# Altium schematic sheet [05] - PERIFERALS DIAGRAM.SchDoc
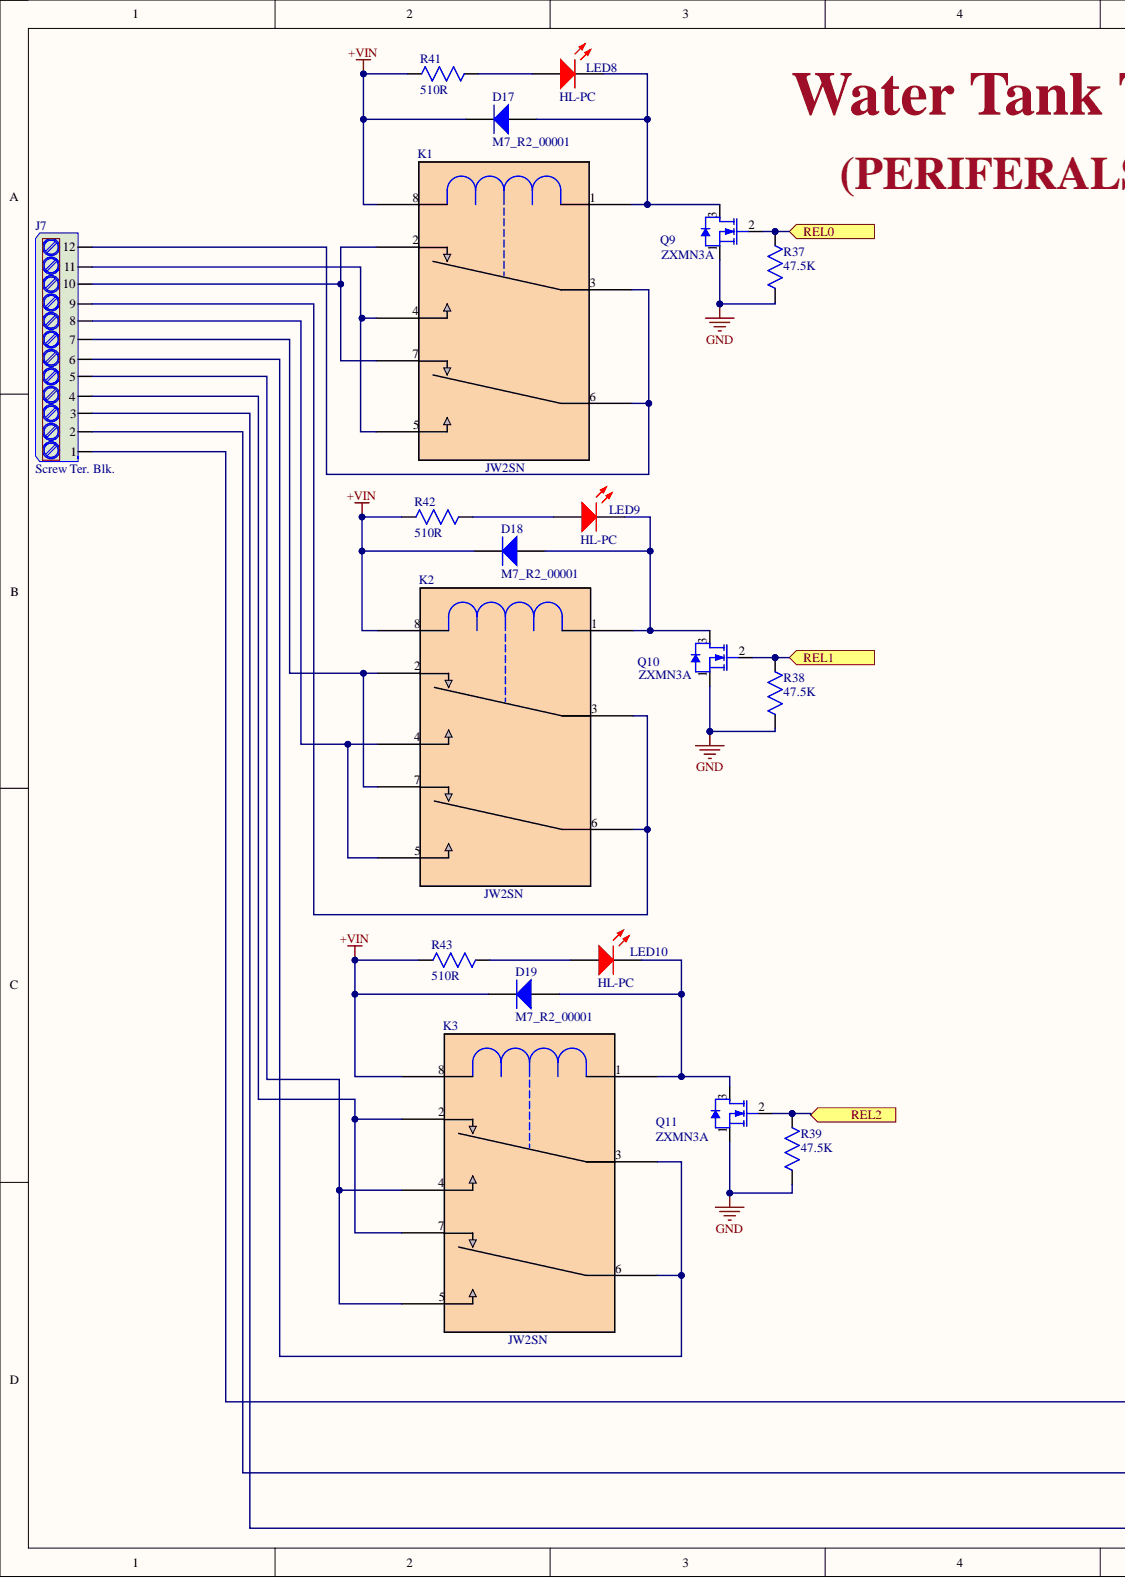
[diagram p0: [05] - PERIFERALS DIAGRAM.SchDoc, left]
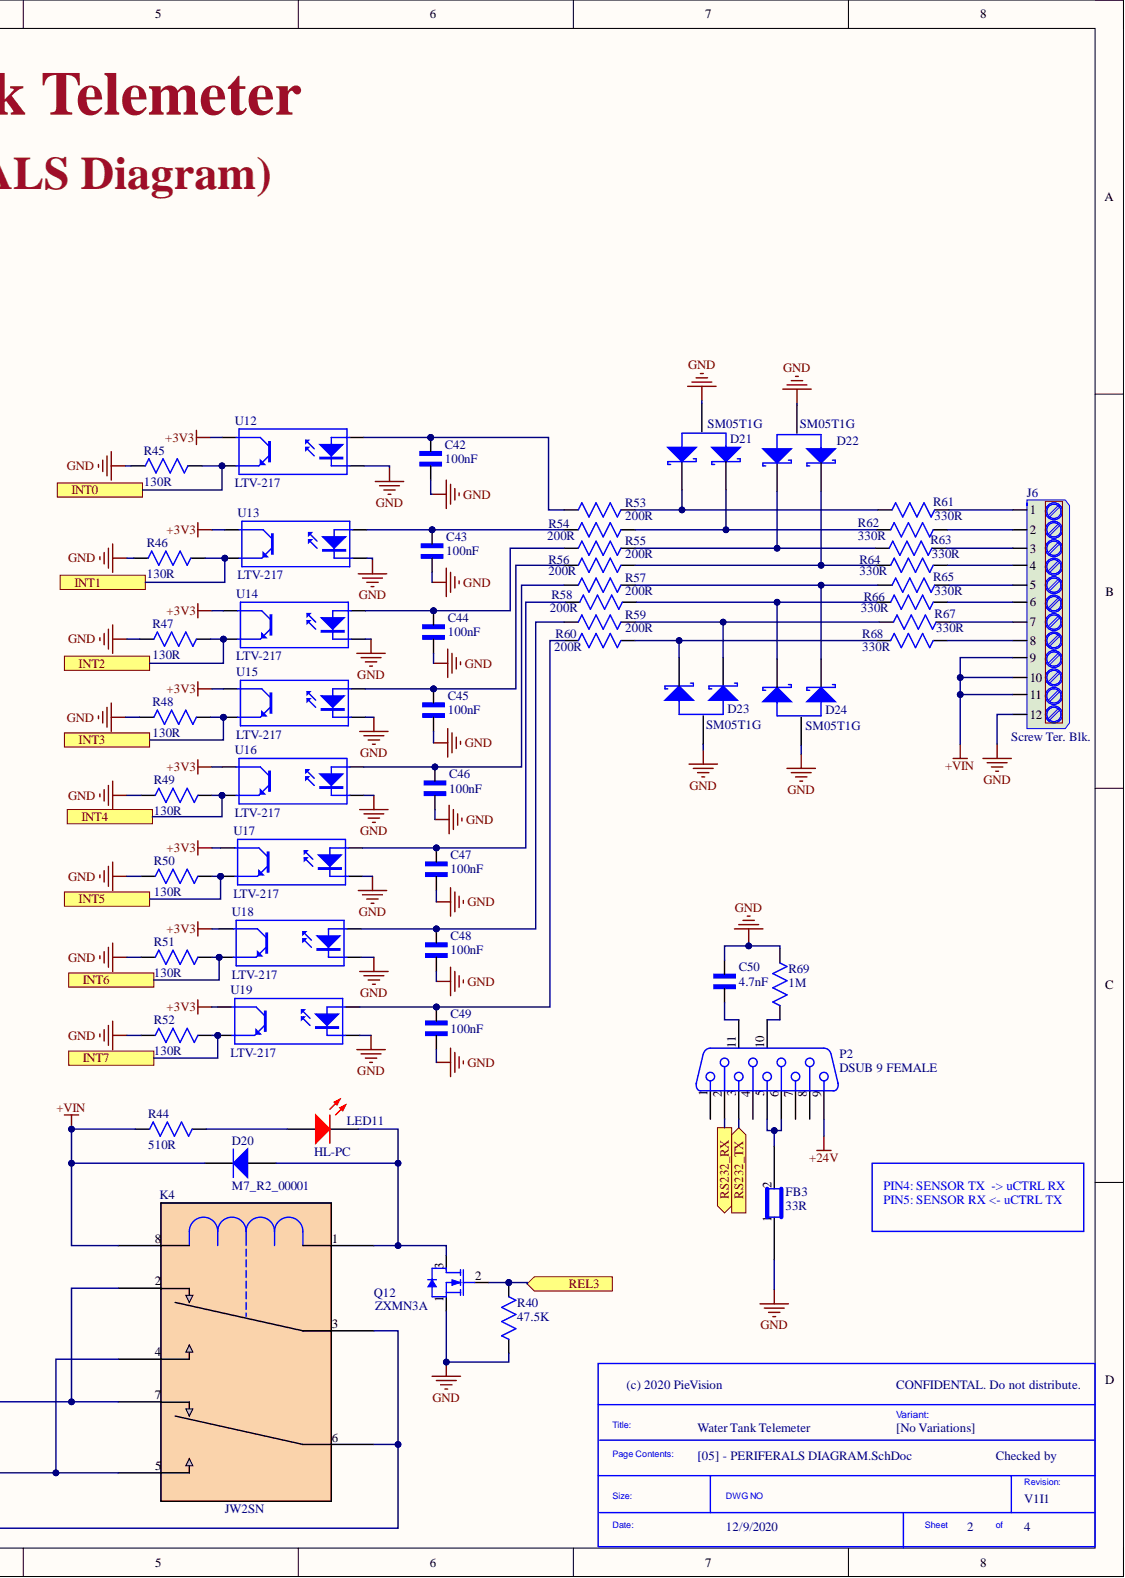
[diagram p1: [05] - PERIFERALS DIAGRAM.SchDoc, right]

## Parts
C42  100nF  [CAP_0805]
C43  100nF  [CAP_0805]
C44  100nF  [CAP_0805]
C45  100nF  [CAP_0805]
C46  100nF  [CAP_0805]
C47  100nF  [CAP_0805]
C48  100nF  [CAP_0805]
C49  100nF  [CAP_0805]
C50  4.7nF  [CAP_0805]
D17  M7_R2_00001  [DID_SMA]
D18  M7_R2_00001  [DID_SMA]
D19  M7_R2_00001  [DID_SMA]
D20  M7_R2_00001  [DID_SMA]
D21  SM05T1G  [SOT-23]
D22  SM05T1G  [SOT-23]
D23  SM05T1G  [SOT-23]
D24  SM05T1G  [SOT-23]
FB3  33R  [FB_0603]
J6  Screw Ter. Blk.  [TERM_BLK_12P]
J7  Screw Ter. Blk.  [TERM_BLK_12P]
K1  JW2SN  [RELAY_DPDT]
K2  JW2SN  [RELAY_DPDT]
K3  JW2SN  [RELAY_DPDT]
K4  JW2SN  [RELAY_DPDT]
LED8  HL-PC  [LED_RED_1206]
LED9  HL-PC  [LED_RED_1206]
LED10  HL-PC  [LED_RED_1206]
LED11  HL-PC  [LED_RED_1206]
P2  DSUB 9 FEMALE  [DSUB9_VF]
Q9  ZXMN3A  [SOT-23]
Q10  ZXMN3A  [SOT-23]
Q11  ZXMN3A  [SOT-23]
Q12  ZXMN3A  [SOT-23]
R37  47.5K  [RES_0805]
R38  47.5K  [RES_0805]
R39  47.5K  [RES_0805]
R40  47.5K  [RES_0805]
R41  510R  [RES_1206]
R42  510R  [RES_1206]
R43  510R  [RES_1206]
R44  510R  [RES_1206]
R45  130R  [RES_0805]
R46  130R  [RES_0805]
R47  130R  [RES_0805]
R48  130R  [RES_0805]
R49  130R  [RES_0805]
R50  130R  [RES_0805]
R51  130R  [RES_0805]
R52  130R  [RES_0805]
R53  200R  [RES_1206]
R54  200R  [RES_1206]
R55  200R  [RES_1206]
R56  200R  [RES_1206]
R57  200R  [RES_1206]
R58  200R  [RES_1206]
R59  200R  [RES_1206]
R60  200R  [RES_1206]
R61  330R  [RES_1206]
R62  330R  [RES_1206]
R63  330R  [RES_1206]
R64  330R  [RES_1206]
R65  330R  [RES_1206]
R66  330R  [RES_1206]
R67  330R  [RES_1206]
R68  330R  [RES_1206]
R69  1M  [RES_0805]
U12  LTV-217  [SSOP-4]
U13  LTV-217  [SSOP-4]
U14  LTV-217  [SSOP-4]
U15  LTV-217  [SSOP-4]
U16  LTV-217  [SSOP-4]
U17  LTV-217  [SSOP-4]
U18  LTV-217  [SSOP-4]
U19  LTV-217  [SSOP-4]

## Ports
INT0, INT1, INT2, INT3, INT4, INT5, INT6, INT7, REL0, REL1, REL2, REL3, RS232_RX, RS232_TX

## Nets
+3V3: U12.4 U13.4 U14.4 U15.4 U16.4 U17.4 U18.4 U19.4
+24V: P2.9
+VIN: D17.2 D18.2 D19.2 D20.2 J6.9 J6.10 J6.11 K1.8 K2.8 K3.8 K4.8 R41.2 R42.2 R43.2 R44.2
GND: C42.2 C43.2 C44.2 C45.2 C46.2 C47.2 C48.2 C49.2 C50.1 D21.3 D22.3 D23.3 D24.3 FB3.1 J6.12 Q9.1 Q10.1 Q11.1 Q12.1 R37.2 R38.2 R39.2 R40.2 R45.2 R46.2 R47.2 R48.1 R49.1 R50.2 R51.2 R52.2 R69.1 U12.2 U13.2 U14.2 U15.2 U16.2 U17.2 U18.2 U19.2
INT0: R45.1 U12.3 ; port INT0
INT1: R46.1 U13.3 ; port INT1
INT2: R47.1 U14.3 ; port INT2
INT3: R48.2 U15.3 ; port INT3
INT4: R49.2 U16.3 ; port INT4
INT5: R50.1 U17.3 ; port INT5
INT6: R51.1 U18.3 ; port INT6
INT7: R52.1 U19.3 ; port INT7
REL0: Q9.2 R37.1 ; port REL0
REL1: Q10.2 R38.1 ; port REL1
REL2: Q11.2 R39.1 ; port REL2
REL3: Q12.2 R40.1 ; port REL3
RS232_RX: P2.2 ; port RS232_RX
RS232_TX: P2.3 ; port RS232_TX
NetC42_1: C42.1 R53.2 U12.1
NetC43_1: C43.1 R54.2 U13.1
NetC44_1: C44.1 R55.2 U14.1
NetC45_1: C45.1 R56.2 U15.1
NetC46_1: C46.1 R57.2 U16.1
NetC47_1: C47.1 R58.2 U17.1
NetC48_1: C48.1 R59.2 U18.1
NetC49_1: C49.1 R60.2 U19.1
NetC50_2: C50.2 P2.11
NetD17_1: D17.1 K1.1 LED8.1 Q9.3
NetD18_1: D18.1 K2.1 LED9.1 Q10.3
NetD19_1: D19.1 K3.1 LED10.1 Q11.3
NetD20_1: D20.1 K4.1 LED11.1 Q12.3
NetD21_1: D21.1 R53.1 R61.2
NetD21_2: D21.2 R54.1 R62.2
NetD22_1: D22.1 R55.1 R63.2
NetD22_2: D22.2 R56.1 R64.2
NetD23_1: D23.1 R59.1 R67.2
NetD23_2: D23.2 R60.1 R68.2
NetD24_1: D24.1 R57.1 R65.2
NetD24_2: D24.2 R58.1 R66.2
NetFB3_2: FB3.2 P2.5 P2.6
NetJ6_1: J6.1 R61.1
NetJ6_2: J6.2 R62.1
NetJ6_3: J6.3 R63.1
NetJ6_4: J6.4 R64.1
NetJ6_5: J6.5 R65.1
NetJ6_6: J6.6 R66.1
NetJ6_7: J6.7 R67.1
NetJ6_8: J6.8 R68.1
NetJ7_1: J7.1 K4.2 K4.7
NetJ7_2: J7.2 K4.4 K4.5
NetJ7_3: J7.3 K4.3 K4.6
NetJ7_4: J7.4 K3.2 K3.7
NetJ7_5: J7.5 K3.4 K3.5
NetJ7_6: J7.6 K3.3 K3.6
NetJ7_7: J7.7 K2.2 K2.7
NetJ7_8: J7.8 K2.4 K2.5
NetJ7_9: J7.9 K2.3 K2.6
NetJ7_10: J7.10 K1.2 K1.7
NetJ7_11: J7.11 K1.4 K1.5
NetJ7_12: J7.12 K1.3 K1.6
NetLED8_2: LED8.2 R41.1
NetLED9_2: LED9.2 R42.1
NetLED10_2: LED10.2 R43.1
NetLED11_2: LED11.2 R44.1
NetP2_10: P2.10 R69.2

Not connected: P2 1 4 7 8

## Library data of the parts
C42-C49 = CAP_0805: .Checked PCB: CHK; Product Description: MLCC (2012) 0805 100nF 50VDC ±5% X7R; Product Name: CL21B104JBCNNNC; Component Kind: Standard; Package: 0805; Value: 100nF; .Checked SCH: CHK; Library Name: Water Tank Telemeter.SchLib
C50 = CAP_0805: .Checked PCB: CHK; Product Description: MLCC (2012) 0805 4.7nF 50VDC ±10% X7R; Product Name: CL21B472KB65PND; Component Kind: Standard; Package: 0805; Value: 4.7nF; .Checked SCH: CHK; Library Name: Water Tank Telemeter.SchLib
D17 = DID_SMA: DIODE STAND. Single 1A 1000V SMT DO214AC (SMA); .Checked PCB: CHK; Component Kind: Standard; Package: SMA; Product Description: DIODE STAND. Single 1A 1000V SMT DO214AC (SMA); Product Name: M7_R2_00001; Value: M7_R2_00001; .Checked SCH: CHK; Library Name: Water Tank Telemeter.SchLib
D18-D20 = DID_SMA: DIODE STAND. Single 1A 1000V SMT DO214AC (SMA); .Checked PCB: .; .Checked SCH: .; Component Kind: Standard; Package: SMA; Product Description: DIODE STAND. Single 1A 1000V SMT DO214AC (SMA); Product Name: M7_R2_00001; Value: M7_R2_00001; Library Name: Water Tank Telemeter.SchLib
D21-D24 = TVS_SOT23: DIODE TVS 5VWM 9.8VC SOT23; .Checked PCB: CHK; Component Kind: Standard; Package: SOT23; Product Description: DIODE TVS 5VWM 9.8VC SOT23; Product Name: SM05T1G; Value: SM05T1G; .Checked SCH: CHK; Library Name: Water Tank Telemeter.SchLib
FB3 = FB_0603: FERRITE BEAD 0603 33R 3A MURATA; .Checked PCB: CHK; Product Name: BLM18PG330SN1D; Component Kind: Standard; Manufacturer: MURATA; Package / Case: 0603; Product Description: FERRITE BEAD 0603 33R 3A MURATA; Value: 33R; .Checked SCH: CHK; Library Name: tracking system schematic library.SchLib
J6 J7 = TERM_BLK_12POS: TERM.BLK.5.00MM 12P PCB TYPE; .Checked PCB: CHK; Product Name: GT301-5.0-12P-12-00AH; Component Kind: Standard; ComponentLink1Description: https://www.molex.com/pdm_docs/sd/395321002_sd.pdf; ComponentLink1URL: https://www.molex.com/pdm_docs/sd/395321002_sd.pdf; Product Description: TERM.BLK.5.00MM 12P PCB TYPE; Value: Screw Ter. Blk.; .Checked SCH: CHK; Library Name: Water Tank Telemeter.SchLib
K1-K4 = REL_DPDT_12V: RELAY POWER 5A 12VDC 2P PANASONIC; .Checked PCB: CHK; Contact Form: DPDT; Product Name: JW2SN-DC12V; Component Kind: Standard; Product Description: RELAY POWER 5A 12VDC 2P PANASONIC; Value: JW2SN; .Checked SCH: CHK; Library Name: Water Tank Telemeter.SchLib
LED8 = LED_RED_1206: LED SMD 1206 RED WATER CLEAR 90-120 mcd 140C; Manufacturer: HONGLITRONIC; .Checked PCB: CHK; Product Name: HL-PC-3216S9AC; Color: RED; Component Kind: Standard; Product Description: LED SMD 1206 RED WATER CLEAR 90-120 mcd 140C; Value: HL-PC; .Checked SCH: CHK; Library Name: Water Tank Telemeter.SchLib
LED9-LED11 = LED_RED_1206: LED SMD 1206 RED WATER CLEAR 90-120 mcd 140C; Manufacturer: HONGLITRONIC; .Checked PCB: .; Product Name: HL-PC-3216S9AC; .Checked SCH: .; Color: RED; Component Kind: Standard; Product Description: LED SMD 1206 RED WATER CLEAR 90-120 mcd 140C; Value: HL-PC; Library Name: Water Tank Telemeter.SchLib
P2 = CONN_DSUB9_VF: CONNECTOR DSUB 9PIN 180C PCB TYPE FEMALE; Manufacturer: CONNFLY; Product Name: DS1034-01-09FBTSI3X; Component Kind: Standard; Product Description: CONNECTOR DSUB 9PIN 180C PCB TYPE FEMALE; Signal Integrity: Connector; Value: DSUB 9 FEMALE; Library Name: Water Tank Telemeter.SchLib
Q9 Q10 = MOSFET_N_SOT23: MOSFET DIS.1.8A 30V N-CH SOT23 ENHANCEMENT SMT; Manufacturer: DIODES; .Checked PCB: .; Product Name: ZXMN3A01FTA; .Checked SCH: .; Component Kind: Standard; Package / Case: SOT23; Product Description: MOSFET DIS.1.8A 30V N-CH SOT23 ENHANCEMENT SMT; Value: ZXMN3A; Library Name: Water Tank Telemeter.SchLib
Q11 Q12 = MOSFET_N_SOT23: MOSFET DIS.1.8A 30V N-CH SOT23 ENHANCEMENT SMT; Manufacturer: DIODES; .Checked PCB: CHK; Product Name: ZXMN3A01FTA; Component Kind: Standard; Package / Case: SOT23; Product Description: MOSFET DIS.1.8A 30V N-CH SOT23 ENHANCEMENT SMT; Value: ZXMN3A; .Checked SCH: CHK; Library Name: Water Tank Telemeter.SchLib
R37 R38 = RES_0805: RES.(2012) 0805 47.5K Ohms 1% 1/8W-S 100PPM; .Checked PCB: .; Package2: 0805; Product Name: 0805S8F4752T5E; .Checked SCH: .; Component Kind: Standard; Product Description: RES.(2012) 0805 47.5K Ohms 1% 1/8W-S 100PPM; Value: 47.5K; Library Name: Water Tank Telemeter.SchLib
R39 R40 = RES_0805: RES.(2012) 0805 47.5K Ohms 1% 1/8W-S 100PPM; .Checked PCB: CHK; Package2: 0805; Product Name: 0805S8F4752T5E; Component Kind: Standard; Product Description: RES.(2012) 0805 47.5K Ohms 1% 1/8W-S 100PPM; Value: 47.5K; .Checked SCH: CHK; Library Name: Water Tank Telemeter.SchLib
R41 = RES_1206: RES.(3216) 1206 510 Ohms 5% 1/4W-S 100PPM; .Checked PCB: CHK; Product Name: 1206S4J0511T5E; Component Kind: Standard; Package: 1206; Product Description: RES.(3216) 1206 510 Ohms 5% 1/4W-S 100PPM; Value: 510R; .Checked SCH: CHK; Library Name: Water Tank Telemeter.SchLib
R42-R44 = RES_1206: RES.(3216) 1206 510 Ohms 5% 1/4W-S 100PPM; .Checked PCB: .; Product Name: 1206S4J0511T5E; .Checked SCH: .; Component Kind: Standard; Package: 1206; Product Description: RES.(3216) 1206 510 Ohms 5% 1/4W-S 100PPM; Value: 510R; Library Name: Water Tank Telemeter.SchLib
R45-R52 = RES_0805: RES.(2012) 0805 130 Ohms 5% 1/8W-S 100PPM; .Checked PCB: CHK; Product Name: 0805S8J0131T5E; Component Kind: Standard; Package: 0805; Product Description: RES.(2012) 0805 130 Ohms 5% 1/8W-S 100PPM; Value: 130R; .Checked SCH: CHK; Library Name: Water Tank Telemeter.SchLib
R53-R60 = RES_1206: RES.(3216) 1206 200 Ohms 1% 1/4W-S AUTOMOTIV; .Checked PCB: CHK; Product Name: CQ06W4F2000T5E; Component Kind: Standard; Package: 1206; Product Description: RES.(3216) 1206 200 Ohms 1% 1/4W-S AUTOMOTIV; Value: 200R; .Checked SCH: CHK; Library Name: Water Tank Telemeter.SchLib
R61-R68 = RES_1206: RES.(3216) 1206 330 Ohms 1% 1/4W-S AUTOMOTIV; .Checked PCB: CHK; Product Name: CQ06W4F3300T5E; Component Kind: Standard; Package: 1206; Product Description: RES.(3216) 1206 330 Ohms 1% 1/4W-S AUTOMOTIV; Value: 330R; .Checked SCH: CHK; Library Name: Water Tank Telemeter.SchLib
R69 = RES_0805: RES.(2012) 0805 1M Ohms 1% 1/8W 100PPM; .Checked PCB: CHK; Product Name: RC2012F105AS; Component Kind: Standard; Package: 0805; Product Description: RES.(2012) 0805 1M Ohms 1% 1/8W 100PPM; Value: 1M; .Checked SCH: CHK; Library Name: Water Tank Telemeter.SchLib
U12-U19 = OPTCOP: IC-217 OPTO. 3750V SSOP4; Manufacturer: LITEON; .Checked PCB: CHK; Product Name: LTV-217-B-G; Component Kind: Standard; Package: SSOP4; Product Description: IC-217 OPTO. 3750V SSOP4; Value: LTV-217; .Checked SCH: CHK; Library Name: Water Tank Telemeter.SchLib
